# Altium schematic sheet Ard_regulator.SchDoc
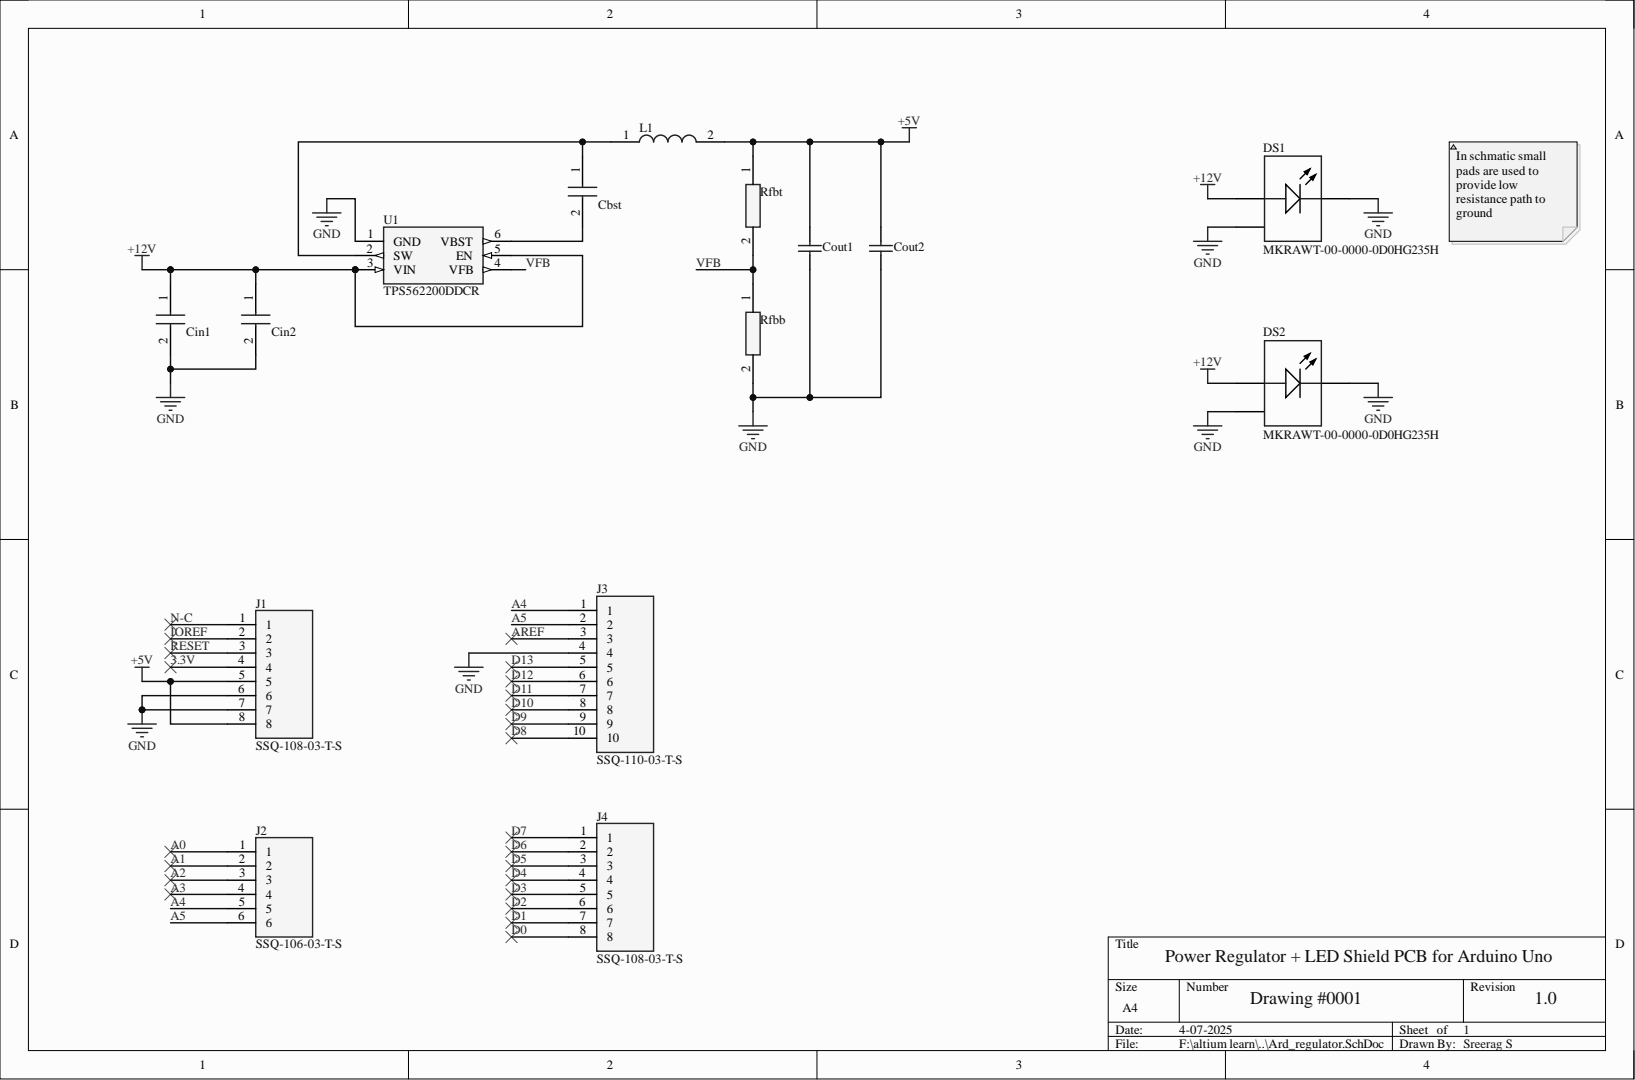
[diagram p0: Ard_regulator.SchDoc]

## Parts
Cbst  08053C104KAT2A  [CAPC2012X94N]  (value not drawn)
Cin1  C2012X5R1V106K085AC  [C2012_Commercial]  (value not drawn)
Cin2  C2012X5R1V106K085AC  [C2012_Commercial]  (value not drawn)
Cout1  C1608X5R1A226M080AC  [CAPC1608X100X20ML10]  (value not drawn)
Cout2  C1608X5R1A226M080AC  [CAPC1608X100X20ML10]  (value not drawn)
DS1  MKRAWT-00-0000-0D0HG235H  [CREE-MK-R-2_V]
DS2  MKRAWT-00-0000-0D0HG235H  [CREE-MK-R-2_V]
J1  SSQ-108-03-T-S  [RHDR8W64P254_1X8_2083X241X851P]
J2  SSQ-106-03-T-S  [RHDR6W64P254_1X6_1575X241X851P]
J3  SSQ-110-03-T-S  [RHDR10W64P254_1X10_2591X241X851P]
J4  SSQ-108-03-T-S  [RHDR8W64P254_1X8_2083X241X851P]
L1  SRN6045-4R7Y  [SRN6045_1]  (value not drawn)
Rfbb  RC0201FR-0710KL  [RESC0603X26N]  (value not drawn)
Rfbt  RC0201FR-0756K2L  [RESC0603X26N]  (value not drawn)
U1  TPS562200DDCR  [SOT95P280X110-6N]

## Nets
+5V: Cout1.2 Cout2.2 J1.5 J1.8 L1.2 Rfbt.1
+12V: Cin1.1 Cin2.1 DS1.1 DS2.1 U1.3(VIN) U1.5(EN)
GND: Cin1.2 Cin2.2 Cout1.1 Cout2.1 DS1.2 DS1.3 DS2.2 DS2.3 J1.6 J1.7 J3.4 Rfbb.2 U1.1(GND)
3.3V: J1.4
A0: J2.1
A1: J2.2
A2: J2.3
A3: J2.4
A4: J2.5 J3.1
A5: J2.6 J3.2
AREF: J3.3
D0: J4.8
D1: J4.7
D2: J4.6
D3: J4.5
D4: J4.4
D5: J4.3
D6: J4.2
D7: J4.1
D8: J3.10
D9: J3.9
D10: J3.8
D11: J3.7
D12: J3.6
D13: J3.5
IOREF: J1.2
N-C: J1.1
RESET: J1.3
VFB: Rfbb.1 Rfbt.2 U1.4(VFB)
NetCbst_1: Cbst.1 L1.1 U1.2(SW)
NetCbst_2: Cbst.2 U1.6(VBST)

## Library data of the parts
Cbst = 08053C104KAT2A: Capacitor; Manufacturer_Name: Kyocera AVX; Arrow Part Number: 08053C104KAT2A; Arrow Price/Stock: https://www.arrow.com/en/products/08053c104kat2a/avx?utm_currency=USD&region=nac; Component Kind: Standard; Datasheet Link: http://datasheets.avx.com/X7RDielectric.pdf; Height: 0.94mm; Manufacturer_Part_Number: 08053C104KAT2A; Mouser Part Number: 581-08053C104K; Mouser Price/Stock: https://www.mouser.co.uk/ProductDetail/KYOCERA-AVX/08053C104KAT2A?qs=EihRZLdKnF2GcTBzDkE%2FiQ%3D%3D
Cin1 Cin2 = C2012X5R1V106K085AC: Capacitor; Manufacturer_Name: TDK; Arrow Part Number: C2012X5R1V106K085AC; Arrow Price/Stock: https://www.arrow.com/en/products/c2012x5r1v106k085ac/tdk?region=nac; Component Kind: Standard; Datasheet Link: https://product.tdk.com/system/files/dam/doc/product/capacitor/ceramic/mlcc/catalog/mlcc_commercial_general_en.pdf; Height: 0.75mm; Manufacturer_Part_Number: C2012X5R1V106K085AC; Mouser Part Number: 810-C2012X5R1V106K0C; Mouser Price/Stock: https://www.mouser.co.uk/ProductDetail/TDK/C2012X5R1V106K085AC?qs=6JAMGB%252BEdky%252BlYMcTLhFyA%3D%3D
Cout1 Cout2 = CMP-2000-05441-1: Chip Capacitor, 22 uF, +/- 20%, 10 V, -55 to 85 degC, 0603 (1608 Metric), RoHS, Tape and Reel; Manufacturer: TDK; PCN Part Number: MLCCPartNumberChange30/Nov/2012; Capacitance: 22µF; Design Resources: 20WNon-IsolatedBuckLEDDriverUsingPLLNK460KG; Kits: 445-9014-KIT-ND-KITMLCC100PF-22UF060310-50V; Online Catalog: CSeries,GeneralPurpose; Other Names: 445-9077-1; Product Training Modules: CSeriesGeneralApplicationsSEAT,CCV,andTVCLDesignToolsGuidetoReplacinganElectrolyticCapacitorwithanMLCC; Temperature Coefficient: X5R; Voltage - Rated: 10V; Applications: GeneralPurpose; Category: Capacitors; Component Kind: Standard; Datasheet URL: http://download.siliconexpert.com/pdfs/2015/4/21/15/54/45/779/tdk_/manual/37024850441143360mlcc_commercial_general_en.pdf; Datasheet Version: Mar-15; Family: CeramicCapacitors; Features: -; Height - Seated (Max): -; Lead Spacing: -; Lead Style: -; Library Name: Altium Content Vault; Manufacturer URL: http://www.tdk.com/; Mounting Type: SurfaceMount,MLCC; Operating Temperature: -55degC~85degC; Package / Case: 0603(1608Metric); Package Description: 2-Pin Surface Mount Device, Body 1.6 x 0.8 mm; Package Reference: 603; Packaging: CutTape(CT); Ratings: -; RoHS Information: RoHSCertificate-MLCC; Series: C; Size / Dimension: 0.063"Lx0.031"W(1.60mmx0.80mm); Standard Package: 1; Thickness (Max): 0.035"(0.90mm); Tolerance: ±20%
DS1 DS2 = CMP-2100-00977-1: LED, White, 12 V, 2-Pin SMD, RoHS, Tape and Reel; Component Kind: Standard; Library Name: Altium Content Vault
J1 J4 = SSQ-108-03-T-S: Connector; Manufacturer_Name: SAMTEC; Arrow Part Number: SSQ-108-03-T-S; Arrow Price/Stock: https://www.arrow.com/en/products/ssq-108-03-t-s/samtec?region=nac; Component Kind: Standard; Datasheet Link: http://suddendocs.samtec.com/prints/ssq-1xx-xx-xxx-x-xx-xxx-xx-x-mkt.pdf; Height: 8.51mm; Manufacturer_Part_Number: SSQ-108-03-T-S; Mouser Part Number: 200-SSQ10803TS; Mouser Price/Stock: https://www.mouser.co.uk/ProductDetail/Samtec/SSQ-108-03-T-S?qs=rU5fayqh%252BE3sJVWDTx5zyQ%3D%3D
J2 = SSQ-106-03-T-S: Connector; Manufacturer_Name: SAMTEC; Arrow Part Number: SSQ-106-03-T-S; Arrow Price/Stock: https://www.arrow.com/en/products/ssq-106-03-t-s/samtec?region=nac; Component Kind: Standard; Datasheet Link: http://suddendocs.samtec.com/prints/ssq-1xx-xx-xxx-x-xx-xxx-xx-x-mkt.pdf; Height: 8.51mm; Manufacturer_Part_Number: SSQ-106-03-T-S; Mouser Part Number: 200-SSQ10603TS; Mouser Price/Stock: https://www.mouser.co.uk/ProductDetail/Samtec/SSQ-106-03-T-S?qs=rU5fayqh%252BE1MgKYVKa8USw%3D%3D
J3 = SSQ-110-03-T-S: Connector; Manufacturer_Name: SAMTEC; Arrow Part Number: SSQ-110-03-T-S; Arrow Price/Stock: https://www.arrow.com/en/products/ssq-110-03-t-s/samtec?region=nac; Component Kind: Standard; Datasheet Link: http://suddendocs.samtec.com/prints/ssq-1xx-xx-xxx-x-xx-xxx-xx-x-mkt.pdf; Height: 8.51mm; Manufacturer_Part_Number: SSQ-110-03-T-S; Mouser Part Number: 200-SSQ11003TS; Mouser Price/Stock: https://www.mouser.co.uk/ProductDetail/Samtec/SSQ-110-03-T-S?qs=rU5fayqh%252BE0uAJE8UTgxfA%3D%3D
L1 = SRN6045-4R7Y: Inductor; Manufacturer_Name: Bourns; Arrow Part Number: SRN6045-4R7Y; Arrow Price/Stock: https://www.arrow.com/en/products/srn6045-4r7y/bourns?utm_currency=USD&region=nac; Component Kind: Standard; Datasheet Link: https://www.bourns.com/data/global/pdfs/SRN6045.pdf; Manufacturer_Part_Number: SRN6045-4R7Y; Mouser Part Number: 652-SRN6045-4R7Y; Mouser Price/Stock: https://www.mouser.co.uk/ProductDetail/Bourns/SRN6045-4R7Y?qs=opBjA1TV902tB4tumIiZhg%3D%3D
Rfbb = RC0201FR-0710KL: Resistor; Manufacturer_Name: KEMET; Component Kind: Standard; Datasheet Link: http://www.yageo.com/documents/recent/PYu-RC0201_51_RoHS_L_8.pdf; Height: 0.26mm; Manufacturer_Part_Number: RC0201FR-0710KL; Mouser Part Number: 603-RC0201FR-0710KL; Mouser Price/Stock: https://www.mouser.co.uk/ProductDetail/YAGEO/RC0201FR-0710KL?qs=FLc6udek5PS1AiJCgpc6bQ%3D%3D
Rfbt = RC0201FR-0756K2L: Resistor; Manufacturer_Name: KEMET; Arrow Part Number: RC0201FR-0756K2L; Arrow Price/Stock: https://www.arrow.com/en/products/rc0201fr-0756k2l/yageo?region=nac; Component Kind: Standard; Datasheet Link: http://www.yageo.com/documents/recent/PYu-RC0201_51_RoHS_L_8.pdf; Height: 0.26mm; Manufacturer_Part_Number: RC0201FR-0756K2L; Mouser Part Number: 603-RC0201FR-0756K2L; Mouser Price/Stock: https://www.mouser.co.uk/ProductDetail/YAGEO/RC0201FR-0756K2L?qs=Q4gDqC5t5%2FD3%2Fkjmz2Nr%2Fw%3D%3D
U1 = TPS562200DDCR: Undefined or Miscellaneous; Manufacturer_Name: Texas Instruments; Arrow Part Number: TPS562200DDCR; Arrow Price/Stock: https://www.arrow.com/en/products/tps562200ddcr/texas-instruments?region=europe; Component Kind: Standard; Datasheet Link: http://www.ti.com/lit/gpn/tps562200; Height: 1.1mm; Manufacturer_Part_Number: TPS562200DDCR; Mouser Part Number: 595-TPS562200DDCR; Mouser Price/Stock: https://www.mouser.co.uk/ProductDetail/Texas-Instruments/TPS562200DDCR?qs=7wMBv%2Ftbz9Jdis0htpD4wA%3D%3D
